# Altium schematic sheet nRF52833-QDAA_revC.SchDoc(nRF52833-QDAA_revC)
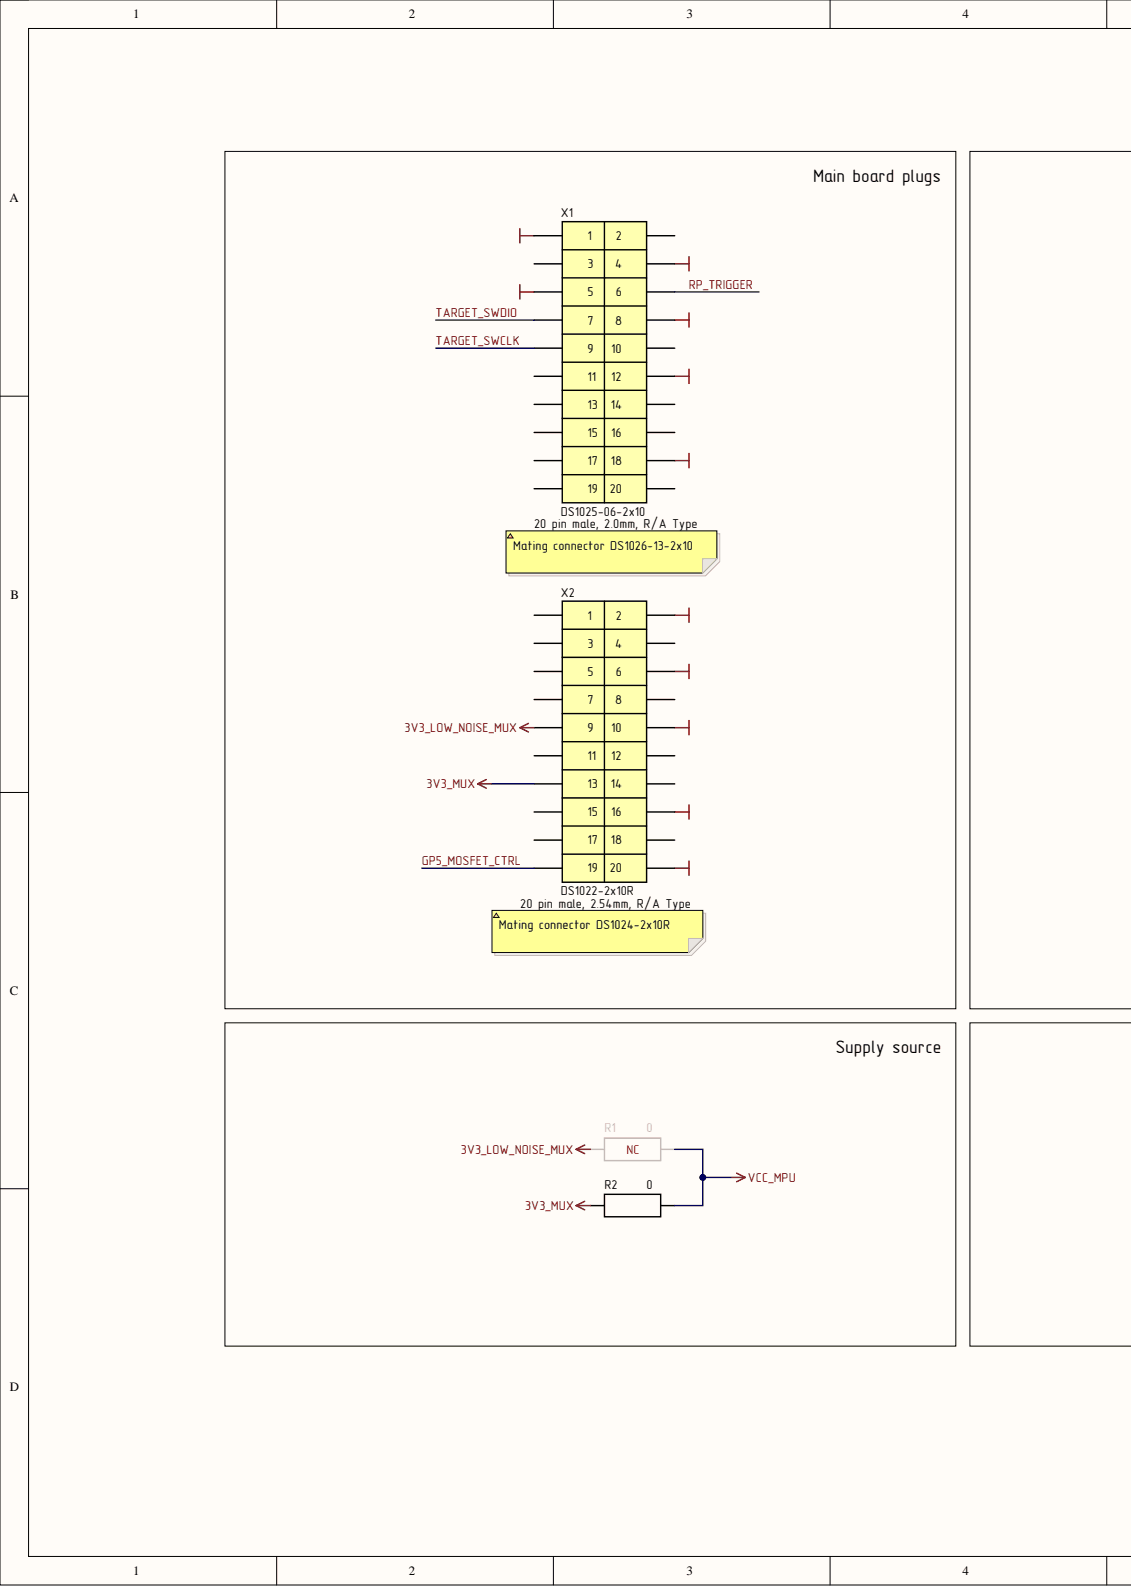
[diagram p0: nRF52833-QDAA_revC.SchDoc, left]
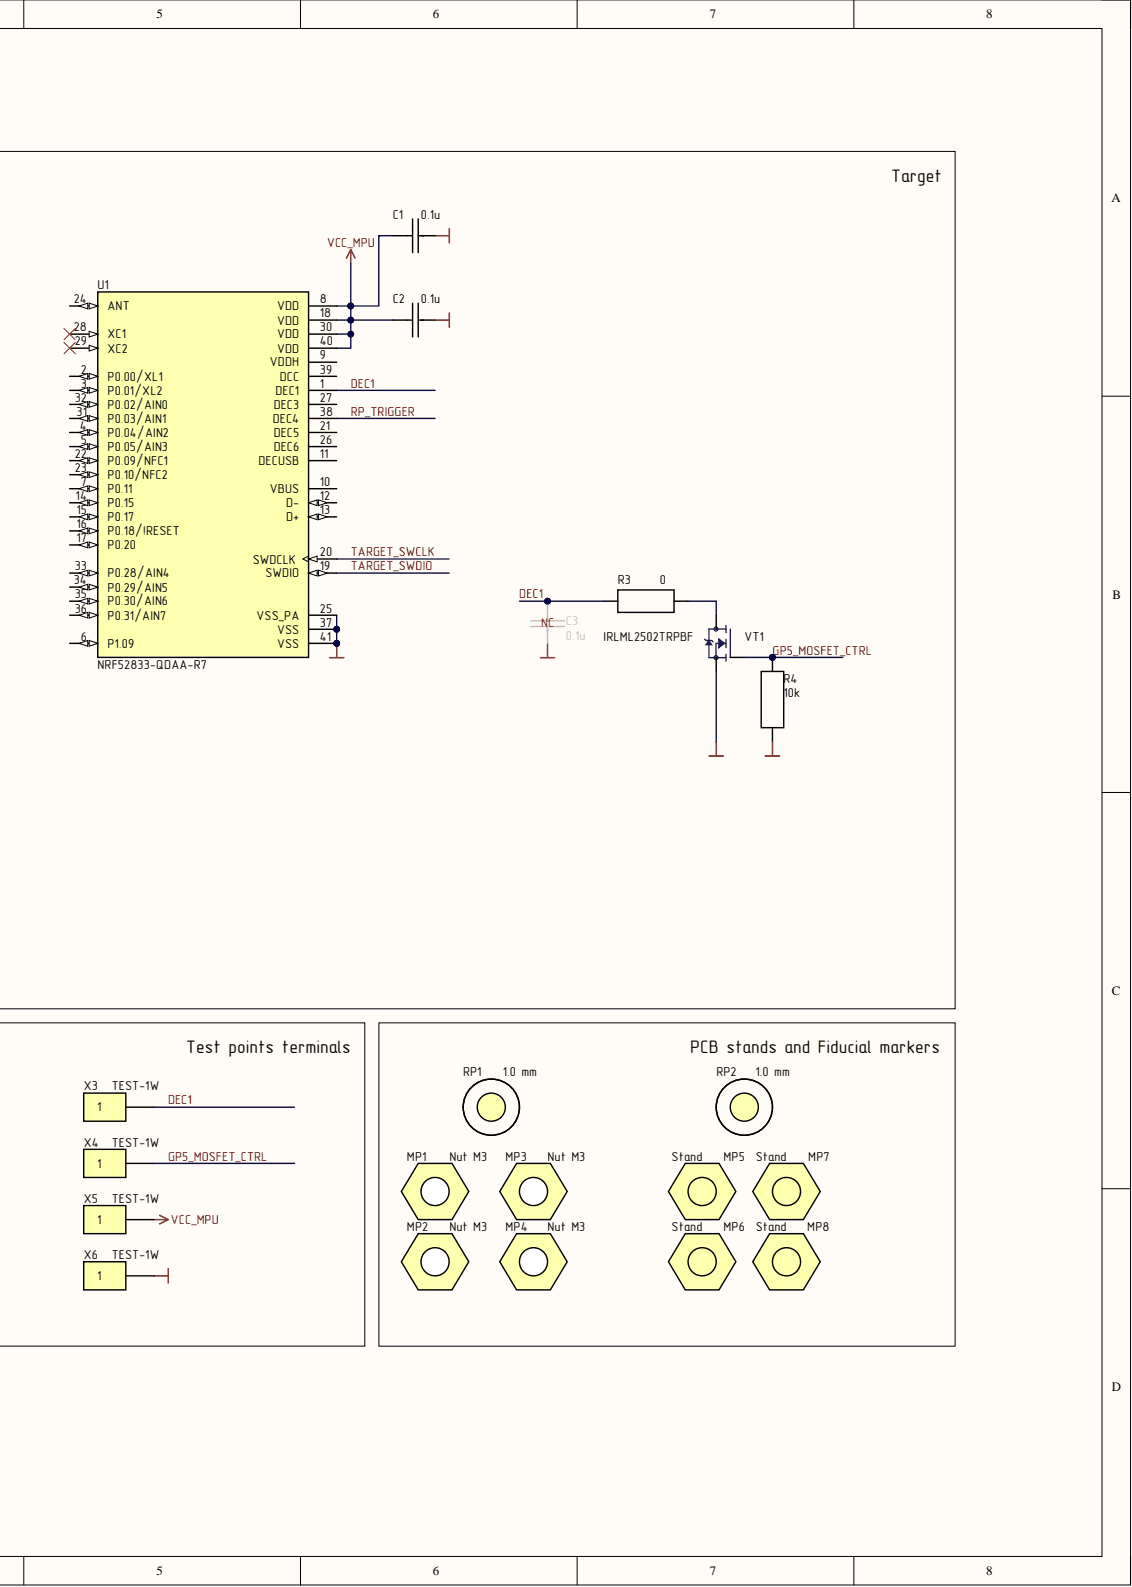
[diagram p1: nRF52833-QDAA_revC.SchDoc, right]

## Parts
C1  value 0.1u  [CH0603C]  (hidden comment: Ceramic capacitor, 0603, 0.1u, ±10%, 50V, X7R, -55...+125°C)
C2  value 0.1u  [CH0603C]  (hidden comment: Ceramic capacitor, 0603, 0.1u, ±10%, 50V, X7R, -55...+125°C)
C3  value 0.1u  [CH0603C]  (hidden comment: Ceramic capacitor, 0603, 0.1u, ±10%, 50V, X7R, -55...+125°C)
MP1  Nut M3  [Stand]  (mechanical)
MP2  Nut M3  [Stand]  (mechanical)
MP3  Nut M3  [Stand]  (mechanical)
MP4  Nut M3  [Stand]  (mechanical)
MP5  Stand  [Stand]
MP6  Stand  [Stand]
MP7  Stand  [Stand]
MP8  Stand  [Stand]
R1  value 0  [CH0603R]  (hidden comment: Resistor thick-film, 0603, 0, ±1%, 0.1W, -55...+125°C)
R2  value 0  [CH0603R]  (hidden comment: Resistor thick-film, 0603, 0, ±1%, 0.1W, -55...+125°C)
R3  value 0  [CH0603R]  (hidden comment: Resistor thick-film, 0603, 0, ±1%, 0.1W, -55...+125°C)
R4  value 10k  [CH0603R]  (hidden comment: Resistor thick-film, 0603, 10k, ±1%, 0.1W, -55...+125°C)
RP1  1.0 mm  [REPER-10]  (no BOM)
RP2  1.0 mm  [REPER-10]  (no BOM)
U1  NRF52833-QDAA-R7  [QFN40P500X500X90-41N]
VT1  IRLML2502TRPBF  [SOT23]
X1  DS1025-06-2x10  [DS1025-06-2x10]
X2  DS1022-2x10R  [DS1022-2x10R]
X3  DEC1  PartNumber: TEST-1W  [TEST-1W 1pin MULTICOMP]
X4  GP5  PartNumber: TEST-1W  [TEST-1W 1pin MULTICOMP]
X5  VCC_MPU  PartNumber: TEST-1W  [TEST-1W 1pin MULTICOMP]
X6  PartNumber: TEST-1W  [TEST-1W 1pin MULTICOMP]  (hidden comment: GND)

## Nets
3V3_LOW_NOISE_MUX: R1.2 X2.9
3V3_MUX: R2.2 X2.13
GND: C1.1 C2.1 C3.1 R4.2 U1.25(VSS_PA) U1.37(VSS) U1.41(VSS) VT1.2 X1.1 X1.4 X1.5 X1.8 X1.12 X1.18 X2.2 X2.6 X2.10 X2.16 X2.20 X6.1
VCC_MPU: C1.2 C2.2 R1.1 R2.1 U1.8(VDD) U1.18(VDD) U1.30(VDD) U1.40(VDD) X5.1
DEC1: C3.2 R3.2 U1.1(DEC1) X3.1
GP5_MOSFET_CTRL: R4.1 VT1.1 X2.19 X4.1
RP_TRIGGER: U1.38(DEC4) X1.6
TARGET_SWCLK: U1.20(SWDCLK) X1.9
TARGET_SWDIO: U1.19(SWDIO) X1.7
NetR3_1: R3.1 VT1.3

Not connected: MP5 1; MP6 1; MP7 1; MP8 1; RP1 1; RP2 1; U1 2(P0.00/XL1) 3(P0.01/XL2) 4(P0.04/AIN2) 5(P0.05/AIN3) 6(P1.09) 7(P0.11) 9(VDDH) 10(VBUS) 11(DECUSB) 12(D-) 13(D+) 14(P0.15) 15(P0.17) 16(P0.18/!RESET) 17(P0.20) 21(DEC5) 22(P0.09/NFC1) 23(P0.10/NFC2) 24(ANT) 26(DEC6) 27(DEC3) 28(XC1) 29(XC2) 31(P0.03/AIN1) 32(P0.02/AIN0) 33(P0.28/AIN4) 34(P0.29/AIN5) 35(P0.30/AIN6) 36(P0.31/AIN7) 39(DCC); X1 2 3 10 11 13 14 15 16 17 19 20; X2 1 3 4 5 7 8 11 12 14 15 17 18

## Library data of the parts
C1-C3 = Capacitor: Manufacturer: Murata; PartNumber: GRM188R71H104K; Type: SMD; Component Kind: Standard; ComponentType: Ceramic capacitor; Description/Value: Ceramic capacitor, 0603, 0.1u, ±10%, 50V, X7R, -55...+125°C; HelpURL: ?; Library Name: Common Lib.SchLib; Package: 0603; Temperature: -55...+125°C; Tolerance: ±10%; TypeDielectric: X7R; Voltage: 50V
MP1-MP4 = nut: PartNumber: Nut M3 DIN 934; Description/Value: Hexagon Nut M3 DIN 934; Library Name: Common Lib.SchLib
MP5-MP8 = stand: PartNumber: PCHSN-10; Description/Value: PCB brass stand, M3, 10mm; Component Kind: Standard; Library Name: Common Lib.SchLib
R1-R3 = Resistor: Manufacturer: Yageo; PartNumber: RC0603FR-070RL; Component Kind: Standard; ComponentType: Resistor thick-film; Description/Value: Resistor thick-film, 0603, 0, ±1%, 0.1W, -55...+125°C; HelpURL: https://datasheet.lcsc.com/lcsc/2009261810_YAGEO-RL0805FR-070R33L_C724024.pdf; Library Name: Common Lib.SchLib; Package: 0603; Power: 0.1W; Temperature: -55...+125°C; Tolerance: ±1%; Type: SMD
R4 = Resistor: Manufacturer: Yageo; PartNumber: RC0603FR-0710KL; Component Kind: Standard; ComponentType: Resistor thick-film; Description/Value: Resistor thick-film, 0603, 10k, ±1%, 0.1W, -55...+125°C; HelpURL: https://datasheet.lcsc.com/lcsc/2009261810_YAGEO-RL0805FR-070R33L_C724024.pdf; Library Name: Common Lib.SchLib; Package: 0603; Power: 0.1W; Temperature: -55...+125°C; Tolerance: ±1%; Type: SMD
RP1 RP2 = CMP-00005-2: Design Item ID: REPER; Source: Common Lib.SchLib; Library Name: Positive Labs Server
U1 = CMP-00118-2: Bluetooth v5.1 (BLE) and NFC With 802.15.4 Combo System on Chip; Description/Value: MPU NRF52833-QDAA-R7; Component Kind: Standard; Design Item ID: NRF52833-QDAA-R7; PartNumber: NRF52833-QDAA-R7; Source: Common Lib.SchLib; Library Name: Positive Labs Server
VT1 = MOSFET N: RoHS Compliant | Industry-leading quality | Fast Switching | Low Profile _less than 1.1mm_ | SOT-23 Footprint; Description/Value: N-MOSFET Transistor, 20V, 4.2A, SOT-23-23; Component Kind: Standard; Library Name: Common Lib.SchLib; Package: SOT-23; PartNumber: IRLML2502TRPBF
X1 = 20-Connector: Description/Value: PLD Connector 20 pin, 2.0mm, Male, DS1025-06-2x10; Component Kind: Standard; ComponentKind: PLD Connector 20 pin, 2.0mm, Male; Library Name: Common Lib.SchLib; PartNumber: DS1025-06-2x10
X2 = 20-Connector: Description/Value: PLD Connector 20 pin, 2.54mm, Male, DS1022-2x10R; Component Kind: Standard; ComponentKind: PLD Connector 20 pin, 2.54mm, Male; Library Name: Common Lib.SchLib; PartNumber: DS1022-2x10R
X3-X6 = 1-Connector: Description/Value: PCB Test Point Through Hole Mount; Component Kind: Standard; Library Name: Common Lib.SchLib
